# Altium schematic sheet [3]Driver2.SchDoc
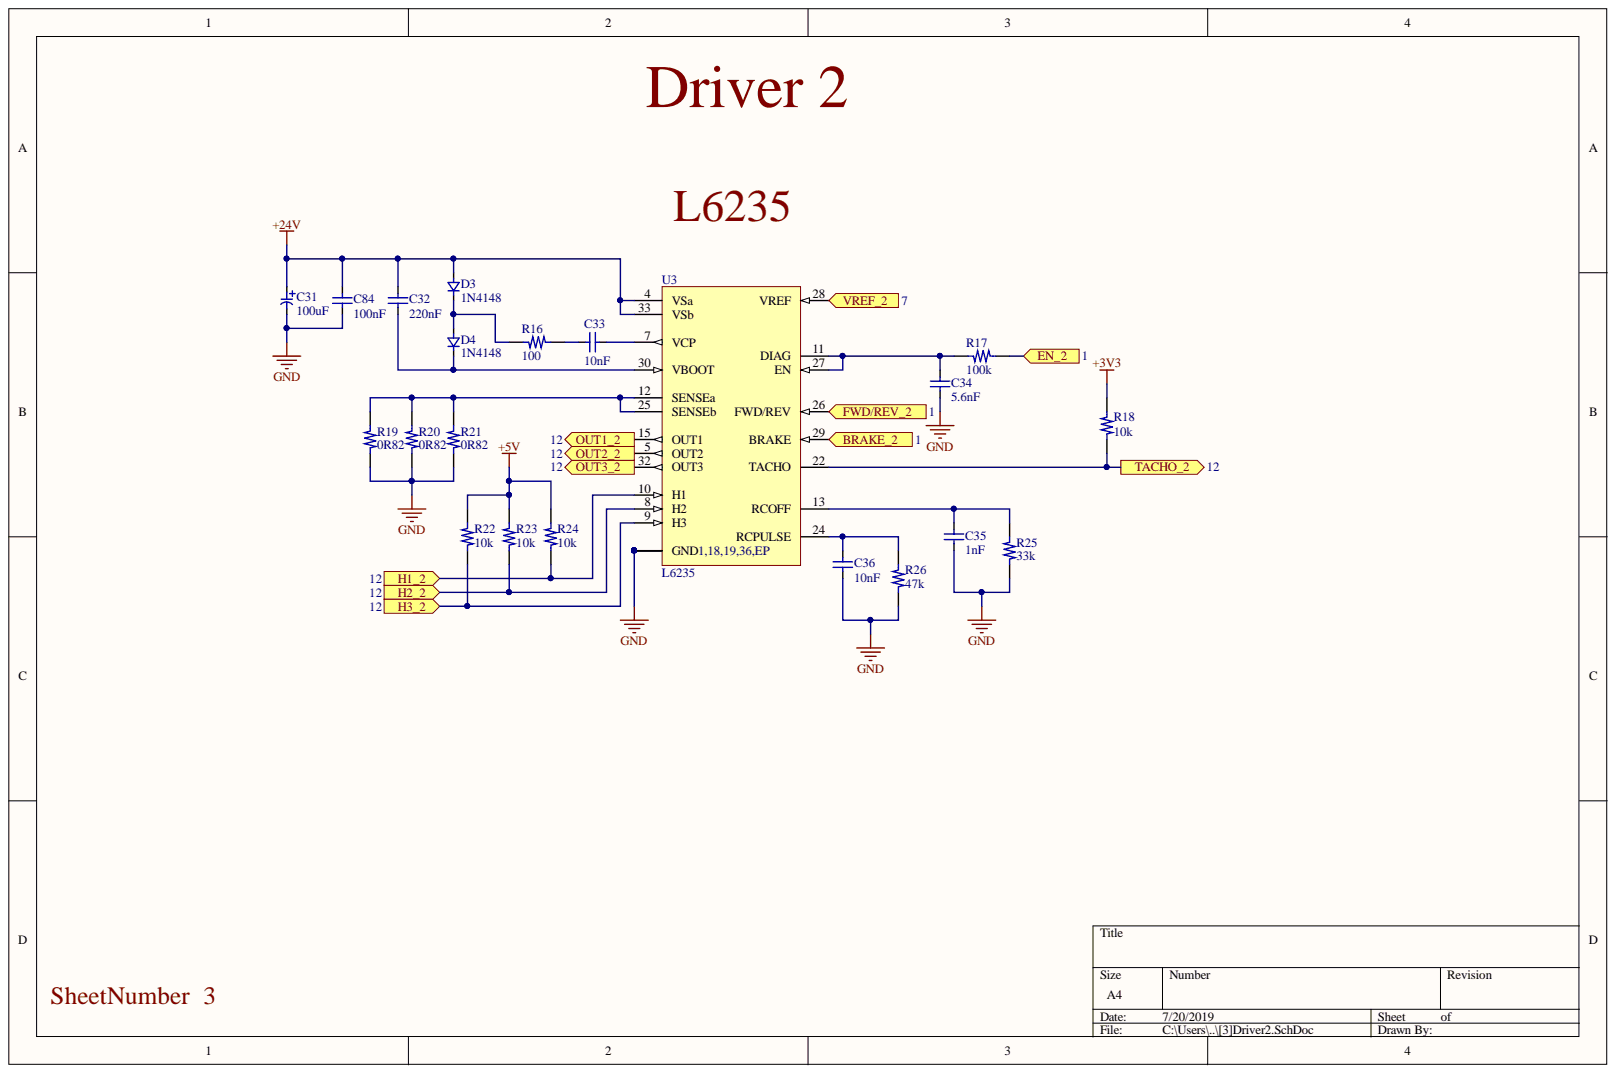
[diagram p0: [3]Driver2.SchDoc]

## Parts
C31  100uF  [Radial SMD 10D]
C32  220nF  [C0805]
C33  10nF  [C0805]
C34  5.6nF  [C0805]
C35  1nF  [C0805]
C36  10nF  [C0805]
C84  100nF  [C0805]
D3  1N4148  [SOD-123]
D4  1N4148  [SOD-123]
R16  100  [R0805]
R17  100k  [R0805]
R18  10k  [R0805]
R19  0R82  [R4122]
R20  0R82  [R4122]
R21  0R82  [R4122]
R22  10k  [R0805]
R23  10k  [R0805]
R24  10k  [R0805]
R25  33k  [R0805]
R26  47k  [R0805]
U3  L6235  [POWERSO36]

## Ports
BRAKE_2, EN_2, FWD/REV_2, H1_2, H2_2, H3_2, OUT1_2, OUT2_2, OUT3_2, TACHO_2, VREF_2

## Nets
+3V3: R18.2
+5V: R22.1 R23.1 R24.1
+24V: C31.1 C32.2 C84.2 D3.1 U3.4(VSa) U3.33(VSb)
GND: C31.2 C34.2 C35.1 C36.1 C84.1 R19.1 R20.1 R21.1 R25.1 R26.1 U3.1 U3.18 U3.19 U3.36 U3.37
BRAKE_2: U3.29(BRAKE) ; port BRAKE_2
EN_2: R17.2 ; port EN_2
FWD/REV_2: U3.26(FWD/REV) ; port FWD/REV_2
H1_2: R24.2 U3.10(H1) ; port H1_2
H2_2: R23.2 U3.8(H2) ; port H2_2
H3_2: R22.2 U3.9(H3) ; port H3_2
OUT1_2: U3.15(OUT1) ; port OUT1_2
OUT2_2: U3.5(OUT2) ; port OUT2_2
OUT3_2: U3.32(OUT3) ; port OUT3_2
TACHO_2: R18.1 U3.22(TACHO) ; port TACHO_2
VREF_2: U3.28(VREF) ; port VREF_2
NetC32_1: C32.1 D4.2 U3.30(VBOOT)
NetC33_1: C33.1 R16.2
NetC33_2: C33.2 U3.7(VCP)
NetC34_1: C34.1 R17.1 U3.11(DIAG) U3.27(EN)
NetC35_2: C35.2 R25.2 U3.13(RCOFF)
NetC36_2: C36.2 R26.2 U3.24(RCPULSE)
NetD3_2: D3.2 D4.1 R16.1
NetR19_2: R19.2 R20.2 R21.2 U3.12(SENSEa) U3.25(SENSEb)

## Library data of the parts
C31 = EEE1JA101P: CAP ALUM 100UF 20% 63V SMD; Mfr 1: Panasonic Electronic Components; Mfr 1 PN: EEE-1JA101P; Component Kind: Standard; Library Name: Components.SchLib
C32 = C0805C224K5RACAUTO: CAP CER 0.22UF 50V X7R 0805; Mfr 1: KEMET; Component Kind: Standard; Library Name: Components.SchLib; Mfr 1 PN: C0805C224K5RACAUTO
C33 C36 = CL21B103KBANFNC: CAP CER 10000PF 50V X7R 0805; Mfr 1: Samsung Electro-Mechanics; Component Kind: Standard; Library Name: Components.SchLib; Mfr 1 PN: CL21B103KBANFNC
C34 = CL21B562KBANNNC: CAP CER 5600PF 50V X7R 0805; Mfr 1: Samsung Electro-Mechanics; Component Kind: Standard; Library Name: Components.SchLib; Mfr 1 PN: CL21B562KBANNNC
C35 = CL21B102KBANFNC: CAP CER 1000PF 50V X7R 0805; Mfr 1: Samsung Electro-Mechanics; Component Kind: Standard; Library Name: Components.SchLib; Mfr 1 PN: CL21B102KBANFNC
C84 = CC0805ZRY5V9BB104: CAP CER 0.1UF 50V Y5V 0805; Mfr 1: Yageo; Component Kind: Standard; Library Name: Components.SchLib; Mfr 1 PN: CC0805ZRY5V9BB104
D3 D4 = 1N4148W-G RHG: DIODE GEN PURP 100V 150MA SOD123; Mfr 1: Taiwan Semiconductor Corporation; Component Kind: Standard; Library Name: Components.SchLib; Mfr 1 PN: 1N4148W-G RHG
R16 = ESR10EZPF1000: RES SMD 100 OHM 1% 0.4W 0805; Mfr 1: Rohm Semiconductor; Component Kind: Standard; Library Name: Components.SchLib; Mfr 1 PN: ESR10EZPF1000
R17 = RC0805FR-07100KL: RES SMD 100K OHM 1% 1/8W 0805; Mfr 1: Yageo; Component Kind: Standard; Library Name: Components.SchLib; Mfr 1 PN: RC0805FR-07100KL
R18 = CRG0805F10K/10: RES SMD 10K OHM 1% 1/8W 0805; Mfr 1: TE Connectivity Passive Product; Component Kind: Standard; Library Name: Components.SchLib; Mfr 1 PN: CRG0805F10K/10
R19-R21 = SMW3R82JT: RES 0.82 OHM 5% 3W 4122; Mfr 1: TE Connectivity Passive Product; Component Kind: Standard; Library Name: Components.SchLib; Mfr 1 PN: SMW3R82JT
R22-R24 = RC0805FR-0710KL: RES SMD 10K OHM 1% 1/8W 0805; Mfr 1: Yageo; Component Kind: Standard; Library Name: Components.SchLib; Mfr 1 PN: RC0805FR-0710KL
R25 = RMCF0805FT33K0: RES 33K OHM 1% 1/8W 0805; Mfr 1: Stackpole Electronics Inc.; Component Kind: Standard; Library Name: Components.SchLib; Mfr 1 PN: RMCF0805FT33K0
R26 = RC0805FR-0747KL: RES SMD 47K OHM 1% 1/8W 0805; Mfr 1: Yageo; Component Kind: Standard; Library Name: Components.SchLib; Mfr 1 PN: RC0805FR-0747KL
U3 = L6235PD: IC MOTOR DRIVER PAR 36POWERSO; Mfr 1: STMicroelectronics; Component Kind: Standard; Library Name: Components.SchLib; Mfr 1 PN: L6235PD
